# Altium schematic sheet TOPSHEET.SchDoc
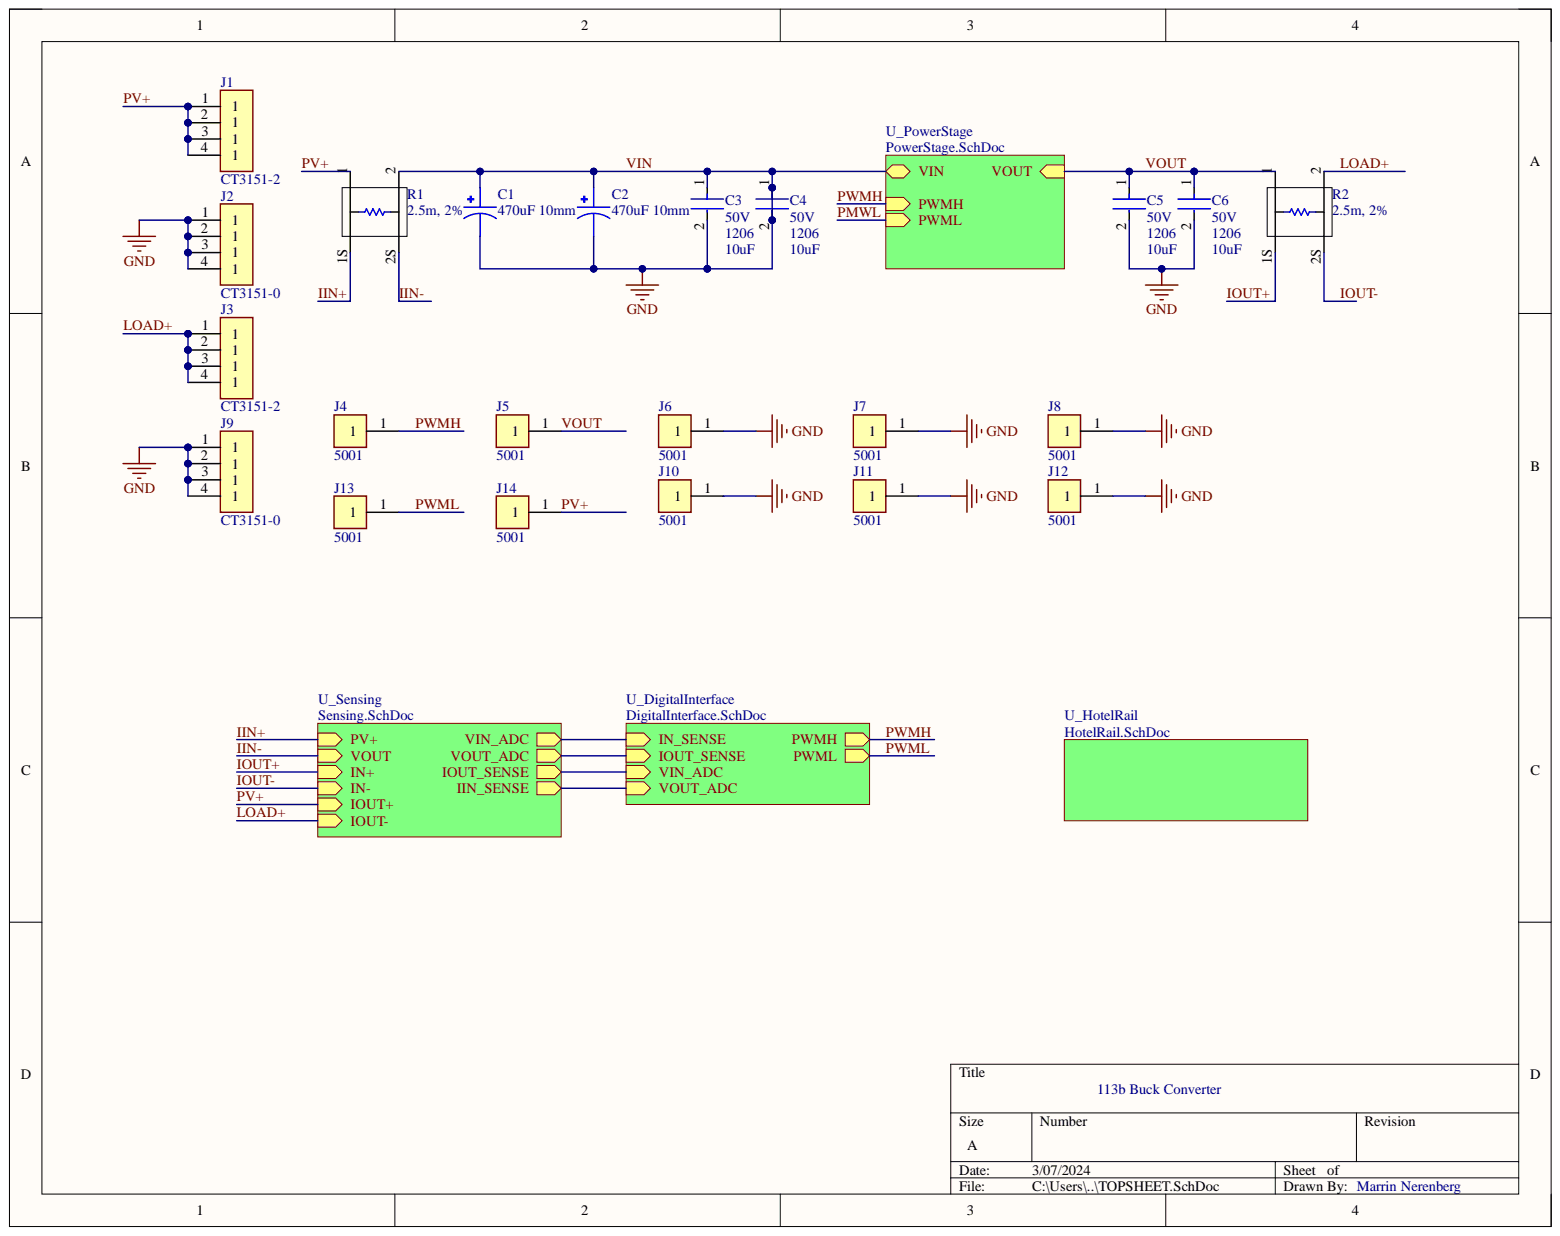
[diagram p0: TOPSHEET.SchDoc]

## Parts
C1  470uF 10mm  [CAPPRD500W60D1000H2200]
C2  470uF 10mm  [CAPPRD500W60D1000H2200]
C3  Capacitance: 10uF  Case Code (Imperial): 1206  Voltage Rating: 50V  [FP-1206-L_3_2_0_2-W_1_6_0-IPC_B]  (hidden comment: 12065C475KAT2A)
C4  Capacitance: 10uF  Case Code (Imperial): 1206  Voltage Rating: 50V  [FP-1206-L_3_2_0_2-W_1_6_0-IPC_B]  (hidden comment: 12065C475KAT2A)
C5  Capacitance: 10uF  Case Code (Imperial): 1206  Voltage Rating: 50V  [FP-1206-L_3_2_0_2-W_1_6_0-IPC_B]  (hidden comment: 12065C475KAT2A)
C6  Capacitance: 10uF  Case Code (Imperial): 1206  Voltage Rating: 50V  [FP-1206-L_3_2_0_2-W_1_6_0-IPC_B]  (hidden comment: 12065C475KAT2A)
J1  CT3151-2  [CALTEST_CT3151-2]
J2  CT3151-0  [CT3151-0]
J3  CT3151-2  [CALTEST_CT3151-2]
J4  5001  [FP-5001-MFG]
J5  5001  [FP-5001-MFG]
J6  5001  [FP-5001-MFG]
J7  5001  [FP-5001-MFG]
J8  5001  [FP-5001-MFG]
J9  CT3151-0  [CT3151-0]
J10  5001  [FP-5001-MFG]
J11  5001  [FP-5001-MFG]
J12  5001  [FP-5001-MFG]
J13  5001  [FP-5001-MFG]
J14  5001  [FP-5001-MFG]
R1  2.5m, 2%  [0612]
R2  2.5m, 2%  [0612]

## Nets
IIN+: R1.1S
IIN-: R1.2S
IOUT+: R2.1S
IOUT-: R2.2S
LOAD+: J3.1 J3.2 J3.3 J3.4 R2.2
PV+: J1.1 J1.2 J1.3 J1.4 J14.1 R1.1
PWMH: J4.1
PWML: J13.1
VIN: C1.1 C1.2 C2.1 C2.2 C3.1 C3.2 C4.1 C4.2 C5.2 C6.2 J2.1 J2.2 J2.3 J2.4 J6.1 J7.1 J8.1 J9.1 J9.2 J9.3 J9.4 J10.1 J11.1 J12.1 R1.2
VOUT: C5.1 C6.1 J5.1 R2.1
IIN_SENSE:
IN_SENSE:
IOUT_SENSE:
PMWL:

## Library data of the parts
C1 C2 = B41856C7477M000: MF: {'manufacturer': u'EPCOS'}; Availability: Unavailable; Component Kind: Standard; MP: B41856C7477M000; Package: None; Price: None
C3-C6 = CMP-2000-07581-3: CAP CER 4.7UF 50V X7R 1206; Manufacturer: Kyocera AVX; Dissipation Factor: 2.5%; Tolerance: 10%; Case Code (Metric): 3216; Case/Package: 1206; Component Kind: Standard; Construction: Flat; Contact Plating: Tin; Depth: 1.6mm; Dielectric: X7R; Lead Free: Lead Free; Length: 3.2mm; Library Name: PV Buck v2 Lib.IntLib; Manufacturer Part Number: 12065C475KAT2A; Max Operating Temperature: 125°C; Min Operating Temperature: -55°C; Mount: Surface Mount; Package Quantity: 1; Packaging: Tape and Reel; Radiation Hardening: No; REACH SVHC: No SVHC; RoHS Compliant: Yes; Series: -; Termination: SMD/SMT; Thickness: 0.07inch; Voltage Rating (DC): 50V; Width: 1.6mm
J1 J3 = CT3151-2_1: Banana Jack Connector Standard Banana Solder Red; MF: {'manufacturer': u'Cal Test'}; Availability: Unavailable; Component Kind: Standard; Library Name: PV Buck v2 Lib.IntLib; MP: CT3151-2; Package: None; Price: None
J2 J9 = CT3151-2: Banana Jack Connector Standard Banana Solder Red; MF: {'manufacturer': u'Cal Test'}; Availability: Unavailable; Component Kind: Standard; Library Name: PV Buck v2 Lib.IntLib; MP: CT3151-2; Package: None; Price: None
J4-J8 J10-J14 = CMP-2000-05181-2: PC TEST POINT MINIATURE BLACK; Manufacturer: Keystone Electronics; Body Material: Bronze; Hole Diameter: 1.6mm; Inside Diameter: 1.27mm; Insulation: Insulated; Number of Contacts: 1; Outside Diameter: 2.54mm; Plating: Silver; Color: Black; Component Kind: Standard; Contact Material: Bronze; Contact Plating: Silver; Diameter: 2.54mm; Flammability Rating: UL94 V-0; Height: 0.3inch; Housing Color: Black; Insulation Material: Nylon; Lead Free: Lead Free; Length: 7.62mm; Library Name: PV Buck v2 Lib.IntLib; Manufacturer Part Number: 5001; Material: Nylon; Mount: Through Hole; Number of Ports: 1; Orientation: Straight; Package Quantity: 100; Packaging: Bulk; REACH SVHC: No SVHC; RoHS Compliant: Yes; Series: THM; Termination: Through Hole
R1 R2 = (no symbol name): 2m, 0612, 2%, 1W; Current: 45A; ID: 79; Mfg: Ohmite; MfgPN: FC4L32R002GER; TempOperatingMax: 155; TempOperatingMin: -55; Voltage: 0.045V; Component Kind: Standard; Library Name: PV Buck v2 Lib.IntLib; Material: Metal Foil; Power: 1W; RoHS: True; SMD: True; Supplier 1: Digi-Key; Supplier Part Number 1: FC4L32R002GER-ND; Tolerance: 2%; Value: 2.5m
